# Altium schematic sheet MIC24085.SchDoc(MIC24085)
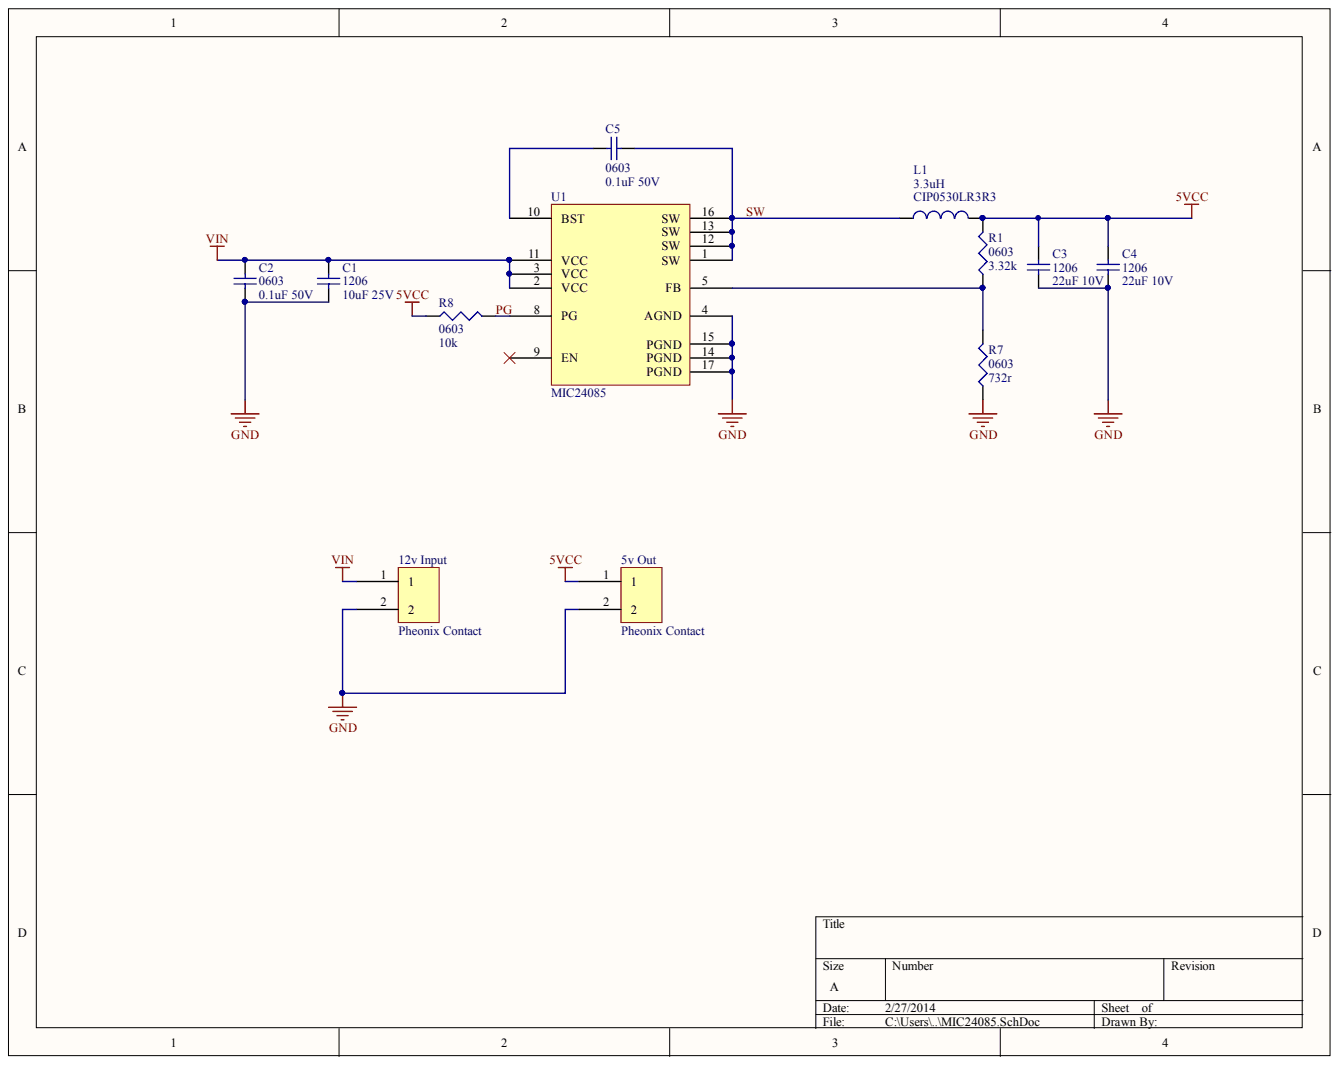
[diagram p0: MIC24085.SchDoc]

## Parts
5v_Out  Pheonix Contact
12v_Input  Pheonix Contact
C1  1206  value 10uF 25V
C2  0603  value 0.1uF 50V
C3  1206  value 22uF 10V
C4  1206  value 22uF 10V
C5  0603  value 0.1uF 50V
L1  CIP0530LR3R3  value 3.3uH
R1  0603  value 3.32k
R7  0603  value 732r
R8  0603  value 10k
U1  MIC24085

## Nets
5VCC: 5v_Out.1 C3.2 C4.2 L1.2 R1.2 R8.1
GND: 5v_Out.2 12v_Input.2 C1.1 C2.1 C3.1 C4.1 R7.1 U1.4(AGND) U1.14(PGND) U1.15(PGND) U1.17(PGND)
VIN: 12v_Input.1 C1.2 C2.2 U1.2(VCC) U1.3(VCC) U1.11(VCC)
PG: R8.2 U1.8(PG)
SW: C5.2 L1.1 U1.1(SW) U1.12(SW) U1.13(SW) U1.16(SW)
NetC5_1: C5.1 U1.10(BST)
NetR1_1: R1.1 R7.2 U1.5(FB)

Not connected: U1 9(EN)

## Library data of the parts
C2 = (no symbol name): Value: 0.1uF 50V; Published: 8-Jun-2000; LatestRevisionDate: 29-May-2009; LatestRevisionNote: IPC-7351 Footprint Added.; PackageReference: C1206; PackageDescription: Chip Capacitor; Publisher: Altium Limited
C3 = (no symbol name): Value: 22uF 10V; Published: 8-Jun-2000; LatestRevisionDate: 29-May-2009; LatestRevisionNote: IPC-7351 Footprint Added.; PackageReference: C1206; PackageDescription: Chip Capacitor; Publisher: Altium Limited
L1 = (no symbol name): Code_IEC: 1005; Published: 8-Jun-2000; LatestRevisionDate: 29-May-2009; LatestRevisionNote: IPC-7351 Footprint Added.; PackageReference: 0402-A; PackageDescription: Chip Inductor; Code_JEITA: 0402; Publisher: Altium Limited
5v_Out 12v_Input C1 C4 C5 R1 R7 R8 U1 = (no symbol name)
  C1 C4 C5: Published: 8-Jun-2000; LatestRevisionDate: 29-May-2009; LatestRevisionNote: IPC-7351 Footprint Added.; PackageReference: C1206; PackageDescription: Chip Capacitor
  R1 R7 R8: Published: 8-Jun-2000; LatestRevisionDate: 29-May-2009; LatestRevisionNote: IPC-7351 Footprint Added.; PackageReference: J1-0603; PackageDescription: Chip Resistor
  C1 C4 C5 R1 R7 R8: Publisher: Altium Limited
